# Altium schematic sheet hv-measurement.SchDoc
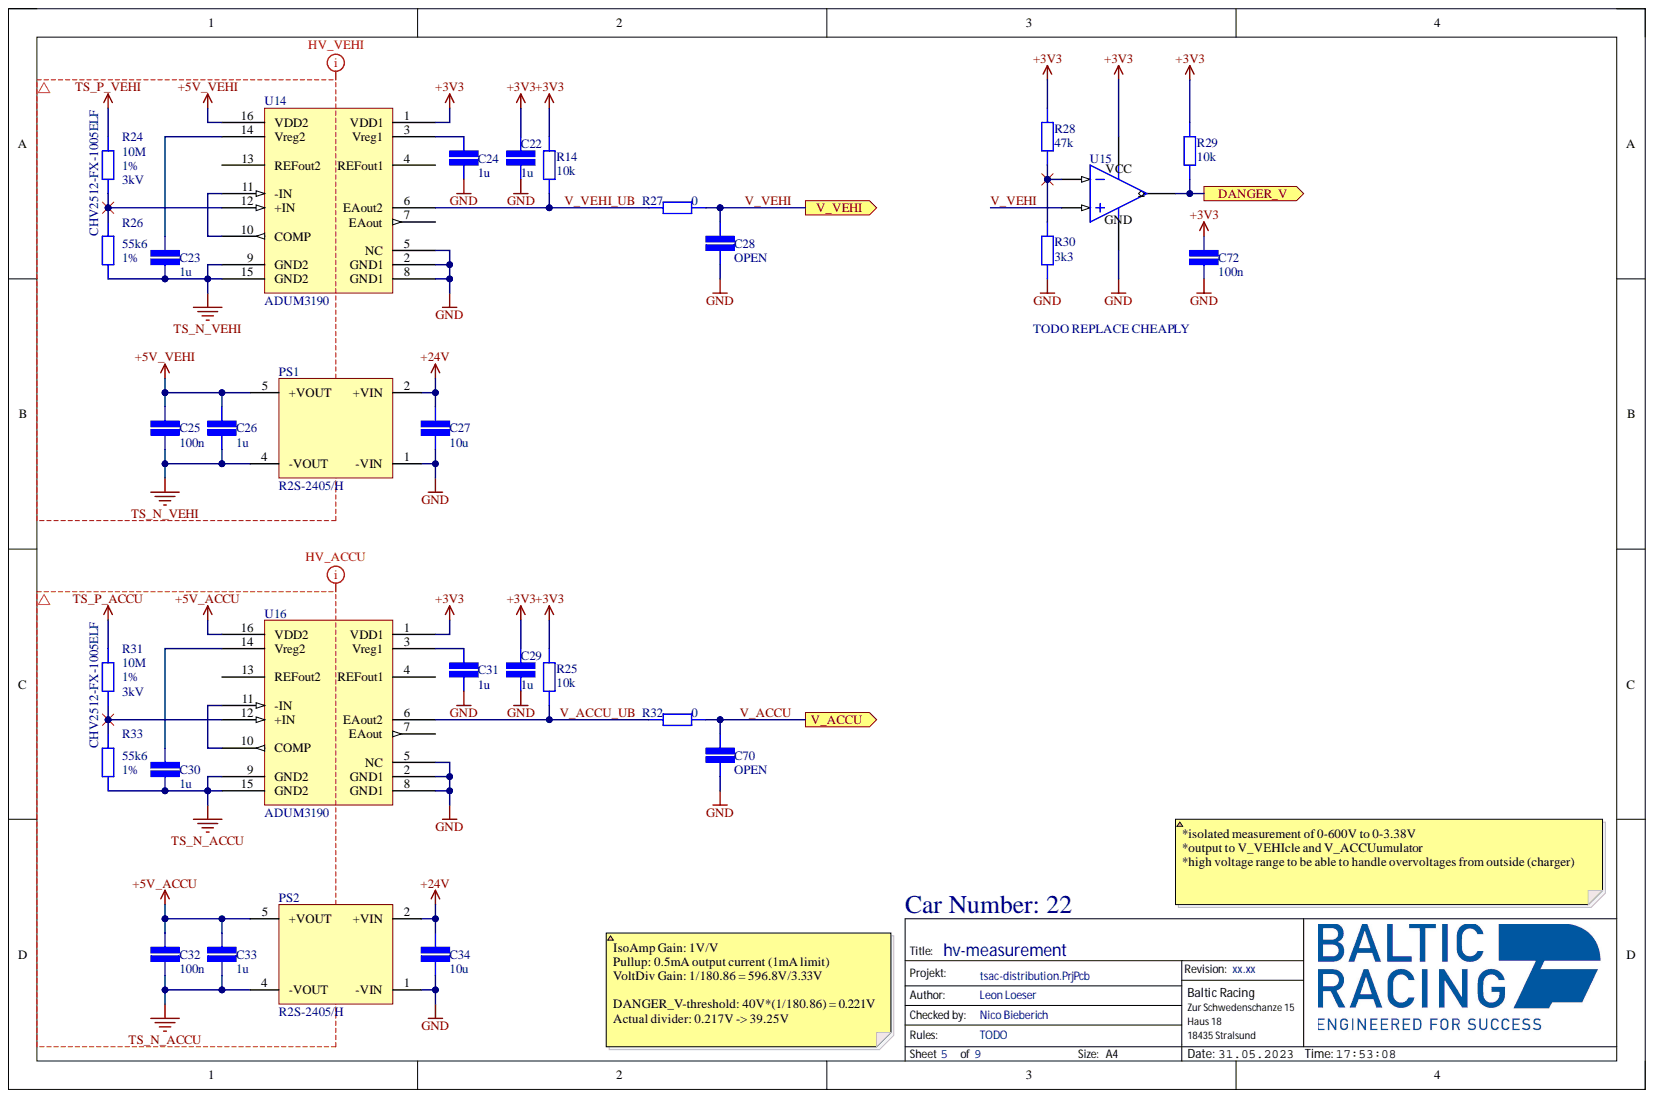
[diagram p0: hv-measurement.SchDoc]

## Parts
C22  value 1u  [CAP 0805/2012]  (hidden comment: SMD Capacitor)
C23  value 1u  [CAP 0805/2012]  (hidden comment: SMD Capacitor)
C24  value 1u  [CAP 0805/2012]  (hidden comment: SMD Capacitor)
C25  value 100n  [CAP 0603/1608]  (hidden comment: SMD Capacitor)
C26  value 1u  [CAP 0805/2012]  (hidden comment: SMD Capacitor)
C27  value 10u  [CAP 1206/3216]  (hidden comment: SMD Capacitor)
C28  value OPEN  [CAP 1206/3216]  (hidden comment: SMD Capacitor)
C29  value 1u  [CAP 0805/2012]  (hidden comment: SMD Capacitor)
C30  value 1u  [CAP 0805/2012]  (hidden comment: SMD Capacitor)
C31  value 1u  [CAP 0805/2012]  (hidden comment: SMD Capacitor)
C32  value 100n  [CAP 0603/1608]  (hidden comment: SMD Capacitor)
C33  value 1u  [CAP 0805/2012]  (hidden comment: SMD Capacitor)
C34  value 10u  [CAP 1206/3216]  (hidden comment: SMD Capacitor)
C70  value OPEN  [CAP 1206/3216]  (hidden comment: SMD Capacitor)
C72  value 100n  [CAP 0603/1608]  (hidden comment: SMD Capacitor)
PS1  R2S-2405/H  [RECOM_R2S]
PS2  R2S-2405/H  [RECOM_R2S]
R14  value 10k  [STACKPOLE CSR0603]  (hidden comment: SMD Resistor)
R24  CHV2512-FX-1005ELF  Resistance: 10M  Tolerance: 1%  Working Voltage: 3kV  [BOURNS_CHV2512]
R25  value 10k  [STACKPOLE CSR0603]  (hidden comment: SMD Resistor)
R26  value 55k6  Tolerance: 1%  [STACKPOLE CSR0603]  (hidden comment: SMD Resistor)
R27  value 0  [STACKPOLE CSR0603]  (hidden comment: SMD Resistor)
R28  value 47k  [STACKPOLE CSR0603]  (hidden comment: SMD Resistor)
R29  value 10k  [STACKPOLE CSR0603]  (hidden comment: SMD Resistor)
R30  value 3k3  [STACKPOLE CSR0603]  (hidden comment: SMD Resistor)
R31  CHV2512-FX-1005ELF  Resistance: 10M  Tolerance: 1%  Working Voltage: 3kV  [BOURNS_CHV2512]
R32  value 0  [STACKPOLE CSR0603]  (hidden comment: SMD Resistor)
R33  value 55k6  Tolerance: 1%  [STACKPOLE CSR0603]  (hidden comment: SMD Resistor)
U14  ADUM3190  [AD_RQ_16]
U15  TODO REPLACE CHEAPLY  [ANALOG_R8]
U16  ADUM3190  [AD_RQ_16]

## Ports
DANGER_V, V_ACCU, V_VEHI

## Nets
+3V3: C22.1 C29.1 C72.1 R14.2 R25.2 R28.2 R29.2 U14.1(VDD1) U15.7 U16.1(VDD1)
+5V_ACCU: C32.1 C33.1 PS2.5(+VOUT) U16.16(VDD2)
+5V_VEHI: C25.1 C26.1 PS1.5(+VOUT) U14.16(VDD2)
+24V: C27.2 C34.2 PS1.2(+VIN) PS2.2(+VIN)
GND: C22.2 C24.2 C27.1 C28.2 C29.2 C31.2 C34.1 C70.2 C72.2 PS1.1(-VIN) PS2.1(-VIN) R30.1 U14.2(GND1) U14.5(NC) U14.8(GND1) U15.4 U16.2(GND1) U16.5(NC) U16.8(GND1)
TS_N_ACCU: C30.2 C32.2 C33.2 PS2.4(-VOUT) R33.1 U16.9(GND2) U16.15(GND2)
TS_N_VEHI: C23.2 C25.2 C26.2 PS1.4(-VOUT) R26.1 U14.9(GND2) U14.15(GND2)
TS_P_ACCU: R31.2
TS_P_VEHI: R24.2
DANGER_V: R29.1 U15.6 ; port DANGER_V
V_ACCU: C70.1 R32.1 ; port V_ACCU
V_ACCU_UB: R25.1 R32.2 U16.6(EAout2)
V_VEHI: C28.1 R27.1 U15.3 ; port V_VEHI
V_VEHI_UB: R14.1 R27.2 U14.6(EAout2)
NetC23_1: C23.1 U14.14(Vreg2)
NetC24_1: C24.1 U14.3(Vreg1)
NetC30_1: C30.1 U16.14(Vreg2)
NetC31_1: C31.1 U16.3(Vreg1)
NetR24_1: R24.1 R26.2 U14.12(+IN)
NetR28_1: R28.1 R30.2 U15.2
NetR31_1: R31.1 R33.2 U16.12(+IN)
NetU14_10: U14.10(COMP) U14.11(-IN)
NetU16_10: U16.10(COMP) U16.11(-IN)

Not connected: U14 4(REFout1) 7(EAout) 13(REFout2); U16 4(REFout1) 7(EAout) 13(REFout2)

## Library data of the parts
C22-C26 C28-C33 C70 C72 = SMD Capacitor: Capacitor with multiple Footprints; Voltage: 6.3V; Component Kind: Standard
  C22-C26 C29-C33 C72: Library Name: Standardbauteile.SchLib
  C28 C70: Library Name: Standardbauteile.IntLib
C27 C34 = SMD Capacitor: Capacitor with multiple Footprints; Voltage: 25V; Component Kind: Standard; Library Name: Standardbauteile.IntLib
PS1 PS2 = R2S-2405/H: 2W DC/DC-Converter 'ECONOLINE' SMD 3kV unreg; V. In: 24; V. Out: 05; mA. Out: 400; Manufacturer: Recom; Efficiency: 85%; Max Input Voltage: 26.4V; Max Output Current: 400mA; Max Output Voltage: 5V; Min Input Voltage: 21.6V; Nominal Input Voltage: 24V; Number of Outputs: 1; Case/Package: SMD/SMT; Category: Voltage Regulators - Switching; Component Kind: Standard; ComponentLink1Description: Datasheet; ComponentLink1URL: https://datasheet.ciiva.com/2177/r2s-r2d-2177940.pdf?src-supplier=Digi-Key; Features: SCP; Height: 8.7mm; Isolation Voltage: 3kV; Lead Free: Lead Free; Length: 12.75mm; Manufacturer Part Number: R2S-2405/H; Max Operating Temperature: 100°C; Max Power Dissipation: 2W; Min Operating Temperature: -40°C; Mount: Surface Mount; Output Power: 2W; Package Quantity: 39; Packaging: Rail/Tube; Pricing 1: 39=17,02000, 78=14,04000, 117=13,79000, 156=13,62000 (USD); RoHS: Yes; RoHS Compliant: Yes; Series: ECONOLINE R2S; Supplier 1: Future Electronics; Supplier Part Number 1: 3107800; Voltage - Output 1: 5V; Weight: 1.4g; Width: 10.7mm; Library Name: Leon.IntLib
R14 R25 R27-R30 R32 = SMD Resistor: Resistor with multiple footprints; Component Kind: Standard; Library Name: Standardbauteile.IntLib
R24 R31 = CHV2512-FX-1005ELF: Res Thick Film 2512 10M Ohm 1% 1W ±100ppm/°C Molded SMD Paper T/R; Manufacturer: Bourns JW Miller; Voltage Rating: 4kV; Case Code (Imperial): 2512; Case Code (Metric): 6432; Case/Package: 2512; Category: Chip SMD Resistors; Component Kind: Standard; ComponentLink1Description: http://www.farnell.com/datasheets/2059762.pdf?src-supplier=Farnell; ComponentLink1URL: http://www.farnell.com/datasheets/2059762.pdf?src-supplier=Farnell; ComponentLink2Description: http://www.alliedelec.com/images/products/datasheets/bm/BOURNS/70479526.pdf?src-supplier=Allied+Electronics; ComponentLink2URL: http://www.alliedelec.com/images/products/datasheets/bm/BOURNS/70479526.pdf?src-supplier=Allied+Electronics; ComponentLink3Description: http://uk.rs-online.com/webdocs/13ff/0900766b813ff012.pdf?src-supplier=RSComponents; ComponentLink3URL: http://uk.rs-online.com/webdocs/13ff/0900766b813ff012.pdf?src-supplier=RSComponents; ComponentLink4Description: http://www.farnell.com/datasheets/1889918.pdf?src-supplier=Newark; ComponentLink4URL: http://www.farnell.com/datasheets/1889918.pdf?src-supplier=Newark; ComponentLink5Description: http://www.farnell.com/datasheets/2059762.pdf?src-supplier=Element14; ComponentLink5URL: http://www.farnell.com/datasheets/2059762.pdf?src-supplier=Element14; ComponentLink6Description: http://www.bourns.com/docs/Product-Datasheets/CHV.pdf?src-supplier=Digi-Key; ComponentLink6URL: http://www.bourns.com/docs/Product-Datasheets/CHV.pdf?src-supplier=Digi-Key; ComponentLink7Description: http://www.bourns.com/data/global/pdfs/CHV.pdf?src-supplier=Avnet; ComponentLink7URL: http://www.bourns.com/data/global/pdfs/CHV.pdf?src-supplier=Avnet; Depth: 3.2mm; Height: 0.03inch; Length: 0.126inch; Manufacturer Part Number: CHV2512-FX-1005ELF; Max Operating Temperature: 155°C; Max Power Dissipation: 1W; Min Operating Temperature: -55°C; Mount: Surface Mount; Number of Terminations: 2; Packaging: Cut Tape; Power Rating: 1W; Pricing 1: 1=0,69000, 10=0,50800, 100=0,29600, 1000=0,16600 (USD); REACH SVHC: No SVHC; Resistor Type: Thick Film; RoHS: Yes; RoHS Compliant: Yes; Stock 1: 27289; Supplier 1: Digi-Key; Supplier Part Number 1: CHV2512-FX-1005ELFCT-ND; Temperature Coefficient: 100ppm/°C; Termination: SMD/SMT; Voltage Rating (DC): 4kV; Width: 0.252inch; Library Name: Leon.IntLib
R26 R33 = SMD Resistor: Resistor with multiple footprints; Value: 55k6; Component Kind: Standard; Library Name: Standardbauteile.IntLib
U14 U16 = ADUM3190: ANALOG DEVICES - ADUM3190ARQZ - Isolation Amplifier, High Stability Error, 2 Amplifier, 2.5 kV, 3V to 20V, QSOP, 16 Pins; -3db Bandwidth: 400kHz; Accuracy: 1%; Bandwidth: 10MHz; Common Mode Rejection Ratio: 72dB; Input Bias Current: 10nA; Input Offset Voltage (Vos): 2.5V; Isolation Voltage: 2.5kV; Max Input Voltage: 1.5V; Max Output Voltage: 5V; Max Power Dissipation: 1.64W; Min Input Voltage: 400mV; Nominal Supply Current: 2.9mA; Number of Amplifiers: 2; Number of Channels: 1; Output Current per Channel: 2mA; Amplifier Type: ISOLATION; Case/Package: QSOP; Category: Integrated Circuits (ICs); Component Kind: Standard; ComponentLink1Description: https://datasheet.ciiva.com/15065/adum3190-preliminary-01-22-2013-15065104.pdf?src-supplier=Digi-Key; ComponentLink1URL: https://datasheet.ciiva.com/15065/adum3190-preliminary-01-22-2013-15065104.pdf?src-supplier=Digi-Key; ComponentLink2Description: https://datasheet.ciiva.com/22616/1692610-22616320.pdf?src-supplier=Element14; ComponentLink2URL: https://datasheet.ciiva.com/22616/1692610-22616320.pdf?src-supplier=Element14; ComponentLink3Description: https://datasheet.ciiva.com/29892/adum3190-29892631.pdf?src-supplier=Avnet; ComponentLink3URL: https://datasheet.ciiva.com/29892/adum3190-29892631.pdf?src-supplier=Avnet; Contact Plating: Tin,Matte; Gain Bandwidth Product: 10MHz; Height: 1.65mm; Lead Free: Contains Lead; Length: 5mm; Manufacturer: Analog Devices; Manufacturer Part Number: ADUM3190ARQZ; Max Operating Temperature: 85°C; Max Supply Voltage: 20V; Min Operating Temperature: -40°C; Min Output Voltage: 400mV; Min Supply Voltage: 3V; Mount: Surface Mount; Number of Pins: 16; Package Quantity: 98; Packaging: Rail/Tube; Power Dissipation: 1.64W; Pricing 1: 1=2,92000, 10=2,62400, 25=2,48040, 100=2,14970, 250=2,03944, 500=1,82998, 1000=1,71600 (USD); Radiation Hardening: No; REACH SVHC: No SVHC; RoHS: Yes; RoHS Compliant: Yes; Series: iCoupler®; Stock 1: 9197; Supplier 1: Digi-Key; Supplier Part Number 1: ADUM3190ARQZ-ND; Supply Current: 2.9mA; Width: 4.01mm; Library Name: Leon.IntLib
U15 = ADCMP391ARZ: 2.3V-5.5V Single Comparator With Known Power-Up State and Open-Drain output; Component Kind: Standard; Library Name: Standardbauteile.IntLib
